# Altium schematic sheet Sheet2.SchDoc(Sheet2)
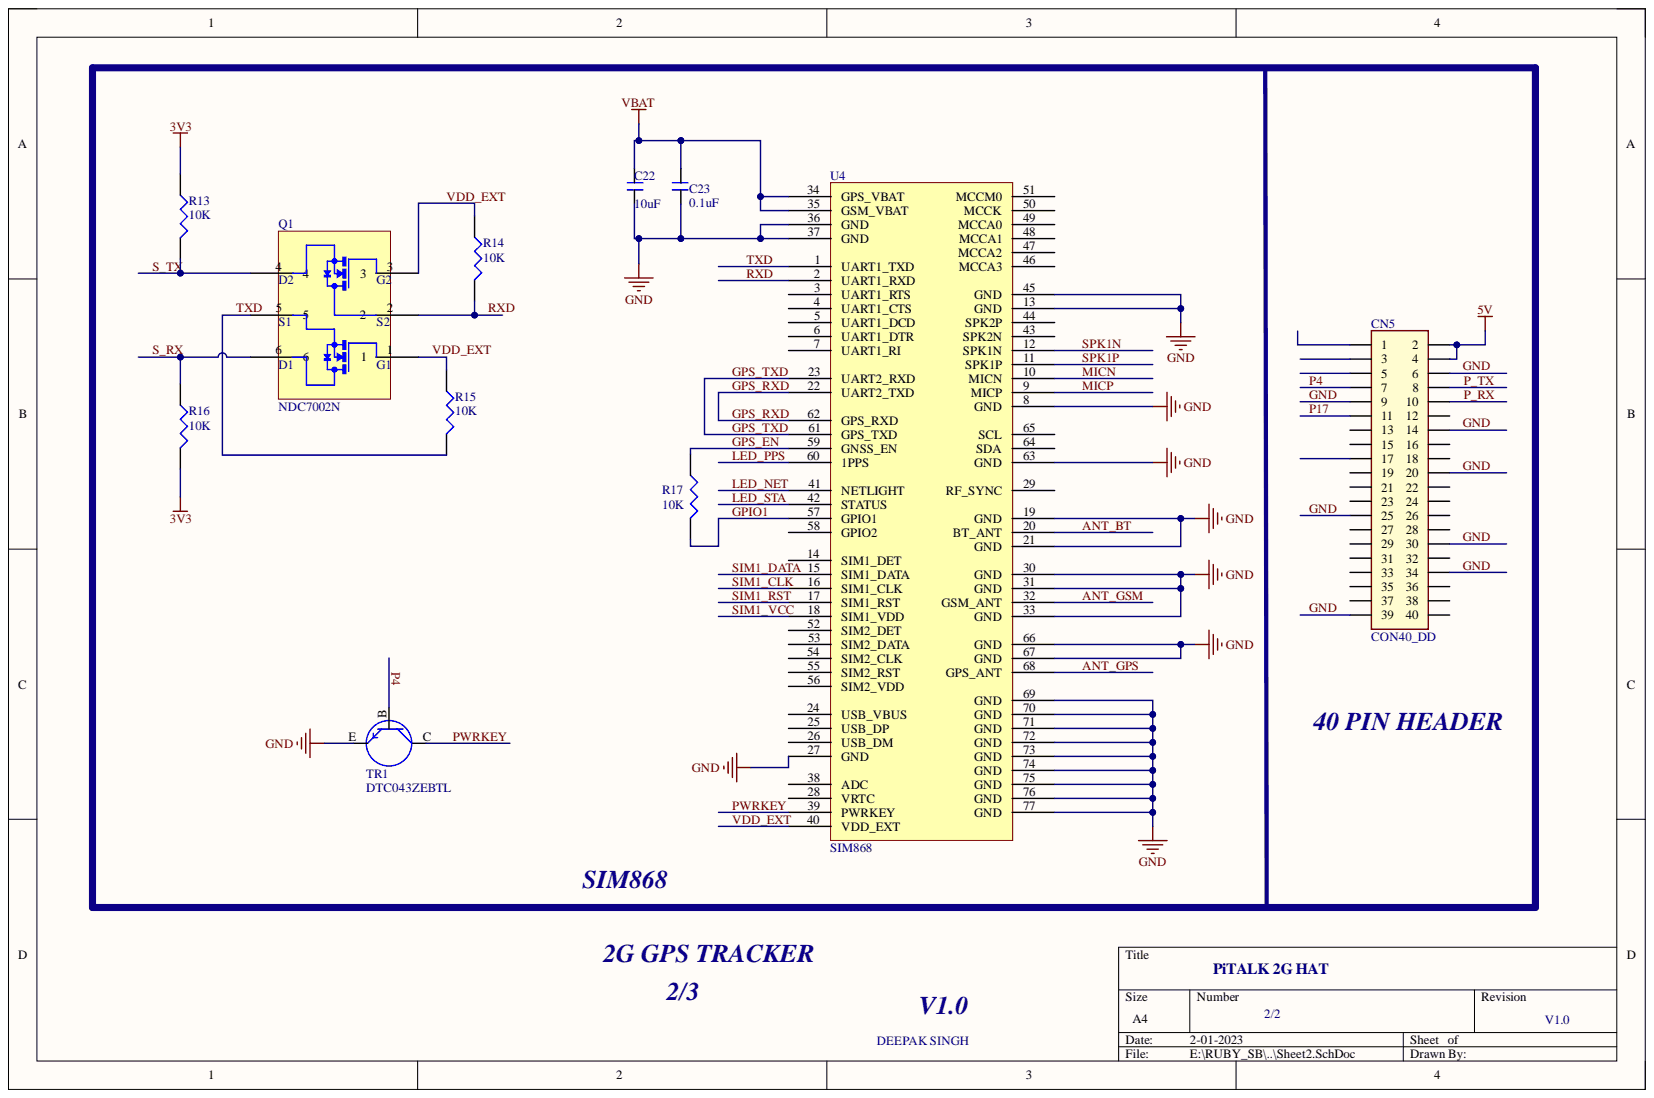
[diagram p0: Sheet2.SchDoc]

## Parts
C22  10uF  [CAP_0603_NONPOLAR]
C23  0.1uF  [CAP_0603_NONPOLAR]
CN5  CON40_DD  [CON40_DD_2p5mm_BOT]  (value not drawn)
Q1  NDC7002N  [SOT-23-6]
R13  10K  [0603]
R14  10K  [0603]
R15  10K  [0603]
R16  10K  [0603]
R17  10K  [0603]
TR1  DTC043ZEBTL  [DTC043ZEBTL]
U4  SIM868  [SIM868]

## Nets
3V3: R13.1 R16.2
5V: CN5.2 CN5.4
VBAT: C22.2 C23.1 U4.34(GPS_VBAT) U4.35(GSM_VBAT)
ANT_BT: U4.20(BT_ANT)
ANT_GPS: U4.68(GPS_ANT)
ANT_GSM: U4.32(GSM_ANT)
GND: C22.1 C23.2 CN5.6 CN5.9 CN5.14 CN5.20 CN5.25 CN5.30 CN5.34 CN5.39 TR1.E U4.8(GND) U4.13(GND) U4.19(GND) U4.21(GND) U4.27(GND) U4.30(GND) U4.31(GND) U4.33(GND) U4.36(GND) U4.37(GND) U4.45(GND) U4.63(GND) U4.66(GND) U4.67(GND) U4.69(GND) U4.70(GND) U4.71(GND) U4.72(GND) U4.73(GND) U4.74(GND) U4.75(GND) U4.76(GND) U4.77(GND)
GPIO1: R17.2 U4.57(GPIO1)
GPS_EN: R17.1 U4.59(GNSS_EN)
GPS_RXD: U4.22(UART2_TXD) U4.62(GPS_RXD)
GPS_TXD: U4.23(UART2_RXD) U4.61(GPS_TXD)
LED_NET: U4.41(NETLIGHT)
LED_PPS: U4.60(1PPS)
LED_STA: U4.42(STATUS)
MICN: U4.10(MICN)
MICP: U4.9(MICP)
P4: CN5.7 TR1.B
P17: CN5.11
P_RX: CN5.10
P_TX: CN5.8
PWRKEY: TR1.C U4.39(PWRKEY)
RXD: Q1.2 R14.2 U4.2(UART1_RXD)
S_RX: Q1.6 R16.1
S_TX: Q1.4 R13.2
SIM1_CLK: U4.16(SIM1_CLK)
SIM1_DATA: U4.15(SIM1_DATA)
SIM1_RST: U4.17(SIM1_RST)
SIM1_VCC: U4.18(SIM1_VDD)
SPK1N: U4.12(SPK1N)
SPK1P: U4.11(SPK1P)
TXD: Q1.5 R15.2 U4.1(UART1_TXD)
VDD_EXT: Q1.1 Q1.3 R14.1 R15.1 U4.40(VDD_EXT)

Not connected: CN5 1 3 5 12 13 15 16 17 18 19 21 22 23 24 26 27 28 29 31 32 33 35 36 37 38 40; U4 3(UART1_RTS) 4(UART1_CTS) 5(UART1_DCD) 6(UART1_DTR) 7(UART1_RI) 14(SIM1_DET) 24(USB_VBUS) 25(USB_DP) 26(USB_DM) 28(VRTC) 29(RF_SYNC) 38(ADC) 43(SPK2N) 44(SPK2P) 46(MCCA3) 47(MCCA2) 48(MCCA1) 49(MCCA0) 50(MCCK) 51(MCCM0) 52(SIM2_DET) 53(SIM2_DATA) 54(SIM2_CLK) 55(SIM2_RST) 56(SIM2_VDD) 58(GPIO2) 64(SDA) 65(SCL)

## Library data of the parts
C22 C23 = CAP_NONPOLAR: Component Kind: Standard; Library Name: dxp_pasl_lib.IntLib
Q1 = NDC7002N: Mosfet Array 2 N-Channel _Dual_ 50V 510mA 700mW Surface Mount SuperSOTâ„¢-6; MF: ON Semiconductor; Availability: Unavailable; Component Kind: Standard; MP: NDC7002N; Package: TSOT-23-6 ON Semiconductor; Price: None; SnapEDA_Link: https://www.snapeda.com/parts/NDC7002N/ON%20Semiconductor/view-part/2045881/?ref=snap; Library Name: dxp_pasl_lib.IntLib
R13-R17 = RES: Component Kind: Standard; Library Name: dxp_pasl_lib.IntLib
U4 = SIM868: GSM/GPRS GPS Communication Modules/GNSS Modules RoHS; Component Kind: Standard; Library Name: dxp_pasl_lib.IntLib
CN5 = CON40_DD: Component Kind: Standard; Library Name: dxp_pasl_lib.IntLib
TR1 = DTC043ZEBTL: Component Kind: Standard; Library Name: dxp_pasl_lib.IntLib
